# Altium schematic sheet A4950_driver.SchDoc
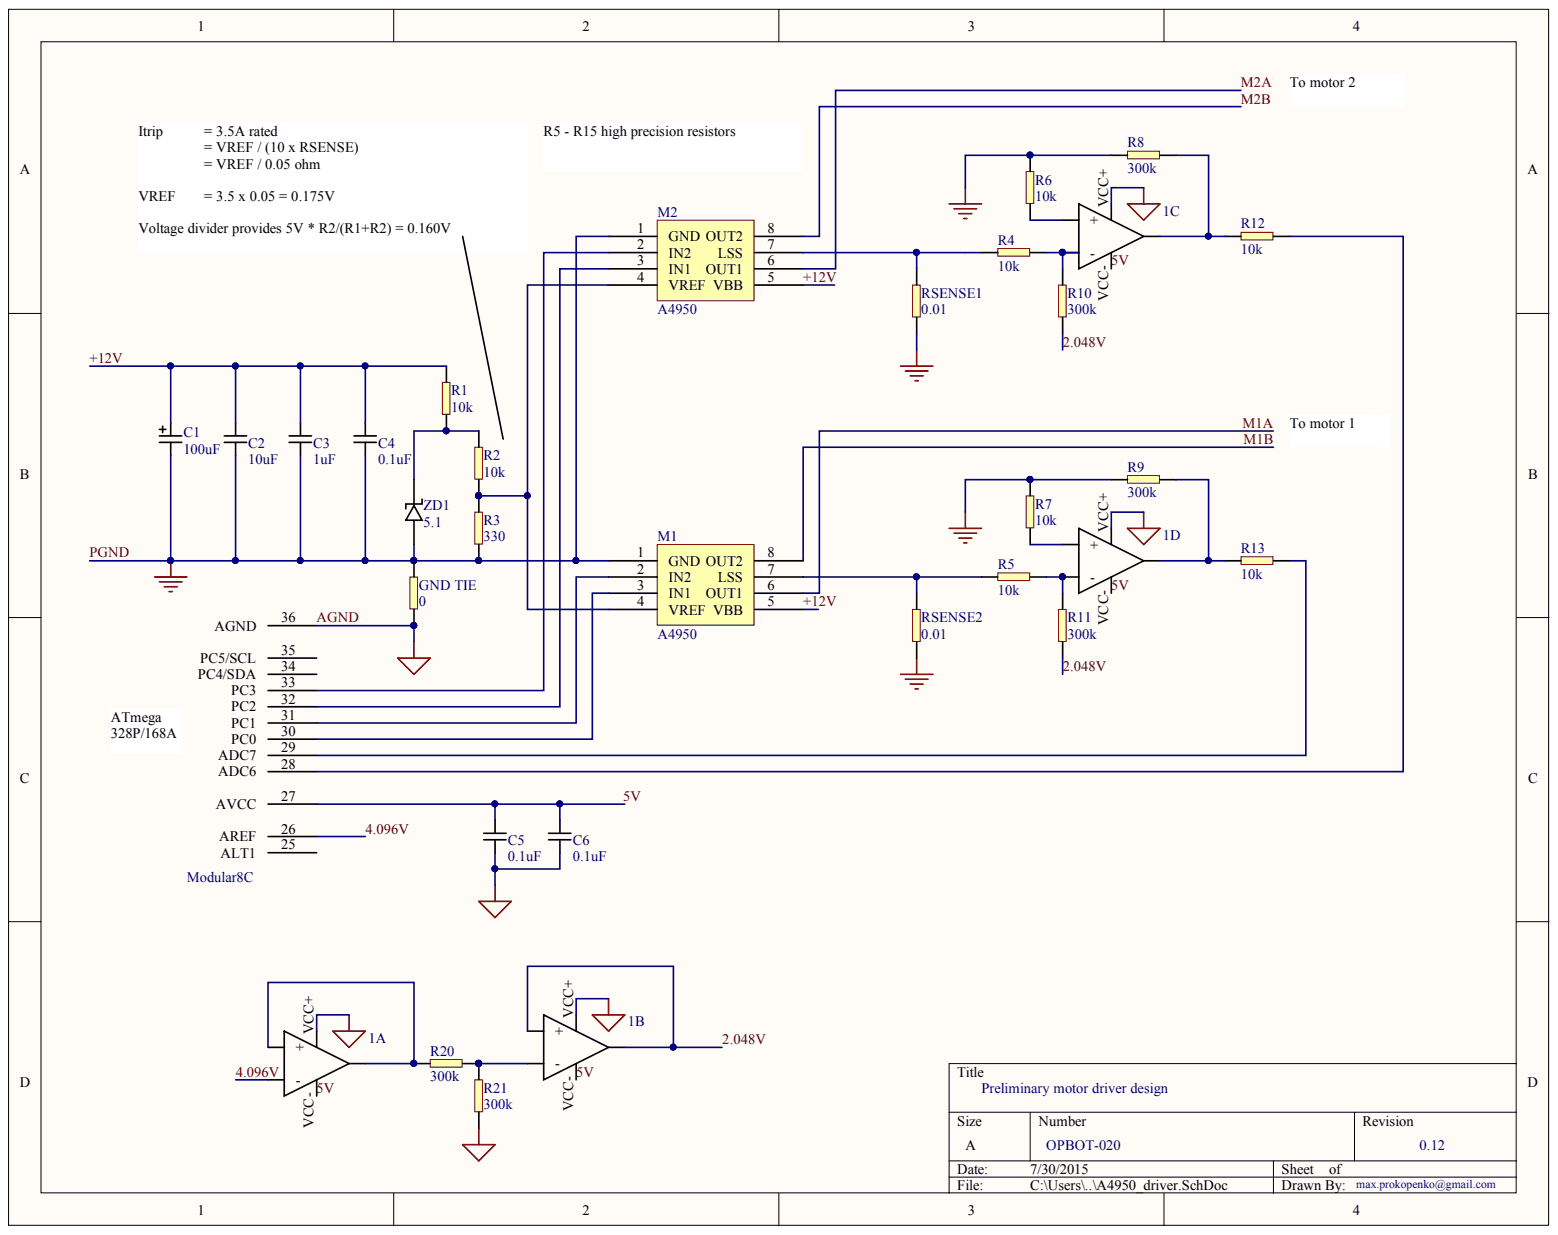
[diagram p0: A4950_driver.SchDoc]

## Parts
1  LM324DT  [SO14]  (value not drawn)  (parts A,B,C,D)
C1  C: 100uF  [RADIAL CAP 6.5mm]  (hidden comment: C 100uF 25V)
C2  C: 10uF  [0603]  (hidden comment: C 10uF 25V)
C3  C: 1uF  [0603]  (hidden comment: C 1uF 25V)
C4  C: 0.1uF  [0603]  (hidden comment: C 0.1uF 25V)
C5  C: 0.1uF  [0603]  (hidden comment: C 0.1uF 25V)
C6  C: 0.1uF  [0603]  (hidden comment: C 0.1uF 25V)
GND_TIE  R 0  [0603]  (value not drawn)
M1  A4950  [SOICN8 thermal pad]
M2  A4950  [SOICN8 thermal pad]
Modular8  Modular8  [modular8]  (part C)
R1  R: 10k  [0603]  (hidden comment: R 10k)
R2  R: 10k  [0603]  (hidden comment: R 10k)
R3  R 330  [0603]  (value not drawn)
R4  R: 10k  [0603]  (hidden comment: R 10k 1%)
R5  R: 10k  [0603]  (hidden comment: R 10k 1%)
R6  R: 10k  [0603]  (hidden comment: R 10k 1%)
R7  R: 10k  [0603]  (hidden comment: R 10k 1%)
R8  R: 300k  [0603]  (hidden comment: R 300k 1%)
R9  R: 300k  [0603]  (hidden comment: R 300k 1%)
R10  R: 300k  [0603]  (hidden comment: R 300k 1%)
R11  R: 300k  [0603]  (hidden comment: R 300k 1%)
R12  R: 10k  [0603]  (hidden comment: R 10k)
R13  R: 10k  [0603]  (hidden comment: R 10k)
R20  R: 300k  [0603]  (hidden comment: R 300k 1%)
R21  R: 300k  [0603]  (hidden comment: R 300k 1%)
RSENSE1  R: 0.01  [1206 SPLIT]  (hidden comment: R 0.01 0.5%)
RSENSE2  R: 0.01  [1206 SPLIT]  (hidden comment: R 0.01 0.5%)
ZD1  Voltage: 5.1  [SOD-323F]  (hidden comment: Zener 5.1V)

## Nets
2.048V: 1.5 1.7 R10.2 R11.2
4.096V: 1.2(-) Modular8.26
5V: 1.VCC- C5.1 C6.1 Modular8.27
+12V: C1.1 C2.1 C3.1 C4.1 M1.5(VBB) M2.5(VBB) R1.1
AGND: 1.VCC+ C1.2 C2.2 C3.2 C4.2 C5.2 C6.2 GND_TIE.1 GND_TIE.2 M1.1(GND) M2.1(GND) Modular8.36 R3.2 R6.2 R7.2 R8.1 R9.1 R21.2 RSENSE1.2 RSENSE2.2 ZD1.1
M1A: M1.6(OUT1)
M1B: M1.8(OUT2)
M2A: M2.6(OUT1)
M2B: M2.8(OUT2)
Net1_1: 1.1 1.3(+) R20.2
Net1_6: 1.6 R20.1 R21.1
Net1_8: 1.8 R8.2 R12.1
Net1_9: 1.9 R4.2 R10.1
Net1_10: 1.10 R6.1
Net1_12: 1.12 R7.1
Net1_13: 1.13 R5.2 R11.1
Net1_14: 1.14 R9.2 R13.1
NetM1_2: M1.2(IN2) Modular8.31
NetM1_3: M1.3(IN1) Modular8.30
NetM1_4: M1.4(VREF) M2.4(VREF) R2.2 R3.1
NetM1_7: M1.7(LSS) R5.1 RSENSE2.1
NetM2_2: M2.2(IN2) Modular8.33
NetM2_3: M2.3(IN1) Modular8.32
NetM2_7: M2.7(LSS) R4.1 RSENSE1.1
NetModular8_28: Modular8.28 R12.2
NetModular8_29: Modular8.29 R13.2
NetR1_2: R1.2 R2.1 ZD1.2

Not connected: Modular8 25 34 35

## Library data of the parts
GND_TIE = R 0: R: 0; Component Kind: Standard; Library Name: RLC.SchLib; Supplier 1: Digi-Key; Supplier Part Number 1: RHM0.0GCT-ND
R3 = R 330: R: 330; Component Kind: Standard; Library Name: RLC.SchLib; Supplier 1: Digi-Key; Supplier Part Number 1: 311-330GRCT-ND
1 = LM324DT: Component Kind: Standard; Supplier 1: Digi-Key; Supplier Part Number 1: 497-1580-1-ND; Library Name: OpBots.SchLib
C1 = C 100uF 25V: Component Kind: Standard; Library Name: RLC.SchLib; Supplier 1: Digi-Key; Supplier 2: Allied; Supplier Part Number 1: PCE3898CT-ND; Supplier Part Number 2: 70257965
C2 = C 10uF 25V: Component Kind: Standard; Library Name: RLC.SchLib; Supplier 1: Digi-Key; Supplier Part Number 1: 445-9015-1-ND
C3 = C 1uF 25V: Component Kind: Standard; Library Name: RLC.SchLib; Supplier 1: Digi-Key; Supplier Part Number 1: 1276-1858-1-ND
C4-C6 = C 0.1uF 25V: Component Kind: Standard; Library Name: RLC.SchLib; Supplier 1: Digi-Key; Supplier Part Number 1: 445-1316-1-ND
M1 M2 = A4950: Component Kind: Standard; Supplier 1: Digi-Key; Supplier Part Number 1: 620-1400-1-ND; Library Name: OpBots.SchLib
Modular8 = Modular8: Component Kind: Standard; Library Name: OpBots.SchLib
R1 R2 R12 R13 = R 10k: Component Kind: Standard; Library Name: RLC.SchLib; Supplier 1: Digi-Key; Supplier Part Number 1: 311-10KGRCT-ND
R4-R7 = R 10k 1%: Component Kind: Standard; Library Name: RLC.SchLib; Supplier 1: Digi-Key; Supplier Part Number 1: 311-10.0KHRCT-ND
R8-R11 R20 R21 = R 300k 1%: Component Kind: Standard; Library Name: RLC.SchLib; Supplier 1: Digi-Key; Supplier Part Number 1: 311-300KHRCT-ND
RSENSE1 RSENSE2 = R 0.01 0.5%: Component Kind: Standard; Library Name: RLC.SchLib; Supplier 1: Digi-Key; Supplier Part Number 1: LVK12R010DERCT-ND
ZD1 = Zener 5.1V: Component Kind: Standard; Supplier 1: Digi-Key; Supplier Part Number 1: UDZ5V1BF-7DICT-ND; Library Name: OpBots.SchLib
